# Altium schematic sheet Connectors.SchDoc(Connectors)
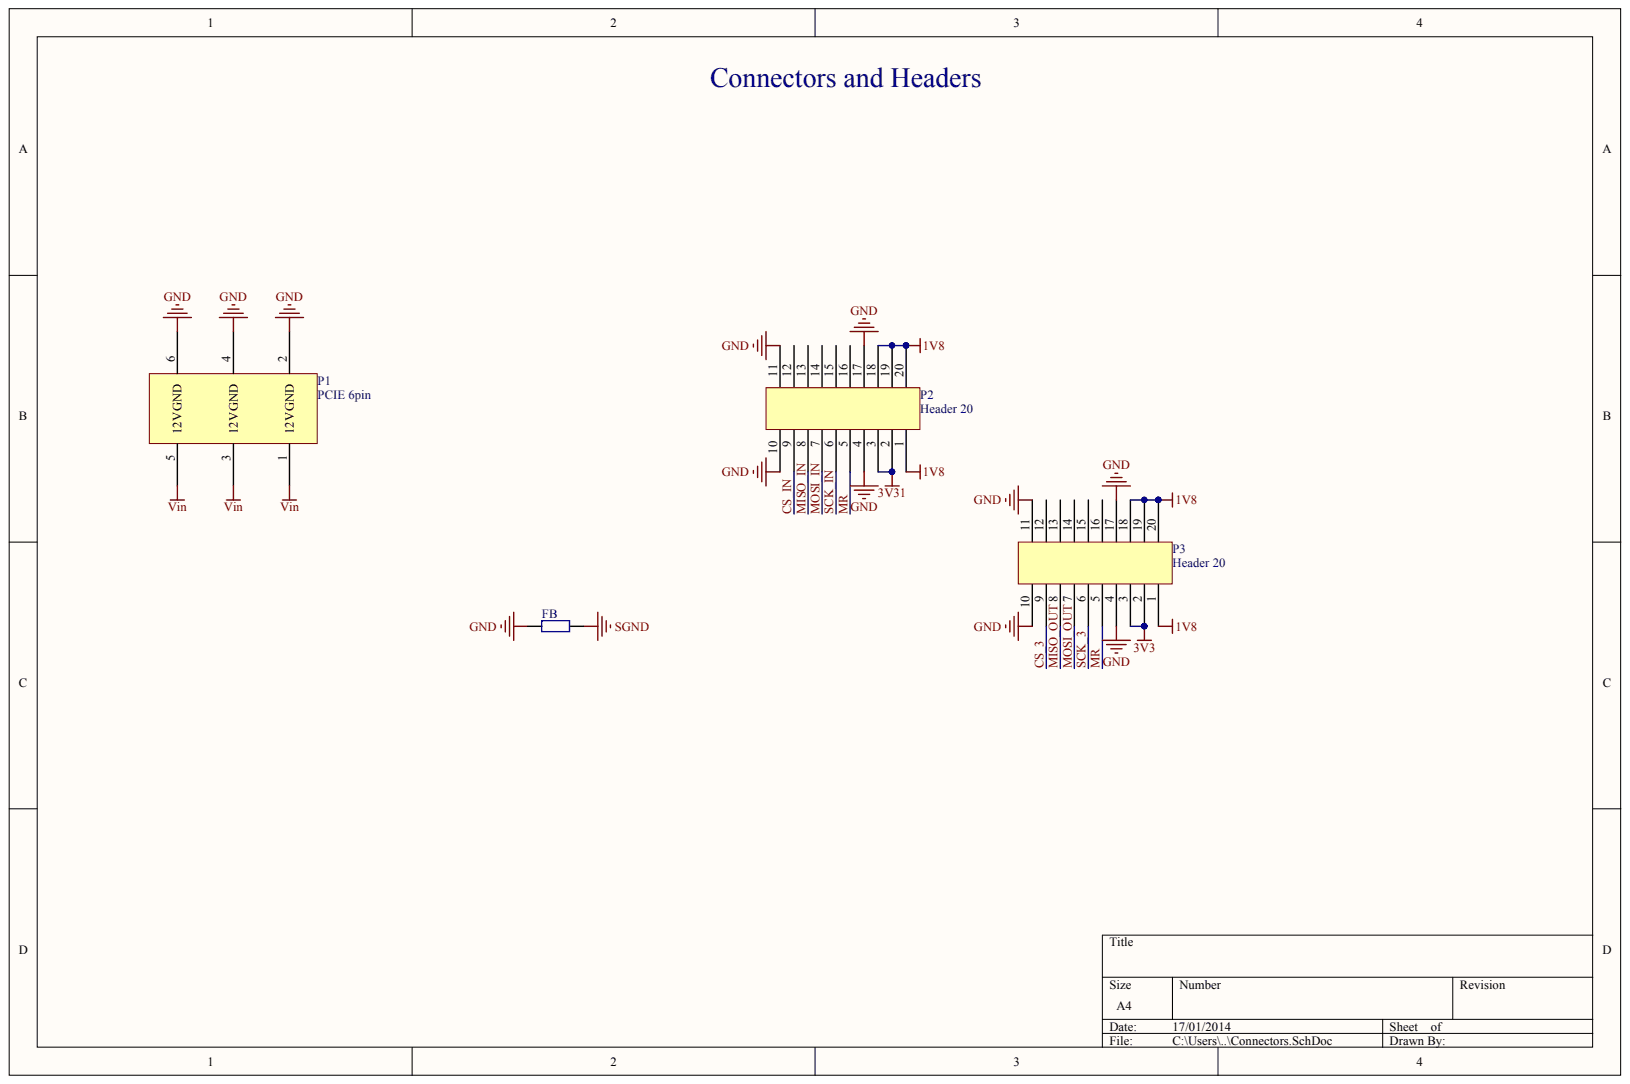
[diagram p0: Connectors.SchDoc]

## Parts
FB  ferrite bread(same as mainboard)  (value not drawn)
P1  PCIE 6pin
P2  Header 20
P3  Header 20

## Nets
1V8: P2.1 P2.18 P2.19 P2.20 P3.1 P3.18 P3.19 P3.20
3V3: P3.2 P3.3
3V31: P2.2 P2.3
GND: FB.1 P1.2(GND) P1.4(GND) P1.6(GND) P2.4 P2.10 P2.11 P2.17 P3.4 P3.10 P3.11 P3.17
SGND: FB.2
VIN: P1.1(12V) P1.3(12V) P1.5(12V)
CS_3: P3.9
CS_IN: P2.9
MISO_IN: P2.8
MISO_OUT: P3.8
MOSI_IN: P2.7
MOSI_OUT: P3.7
MR: P2.5 P3.5
SCK_3: P3.6
SCK_IN: P2.6

Not connected: P2 12 13 14 15 16; P3 12 13 14 15 16

## Library data of the parts
FB = (no symbol name): Value: 0; Published: 8-Jun-2000; LatestRevisionDate: 17-Jul-2002; LatestRevisionNote: Re-released for DXP Platform.; PackageReference: AXIAL-0.4; PackageDescription: Axial Device, Thru-Hole; 2 Leads; 0.4 in Pin Spacing; Publisher: Altium Limited
